# Altium schematic sheet 1_Power_Path.SchDoc
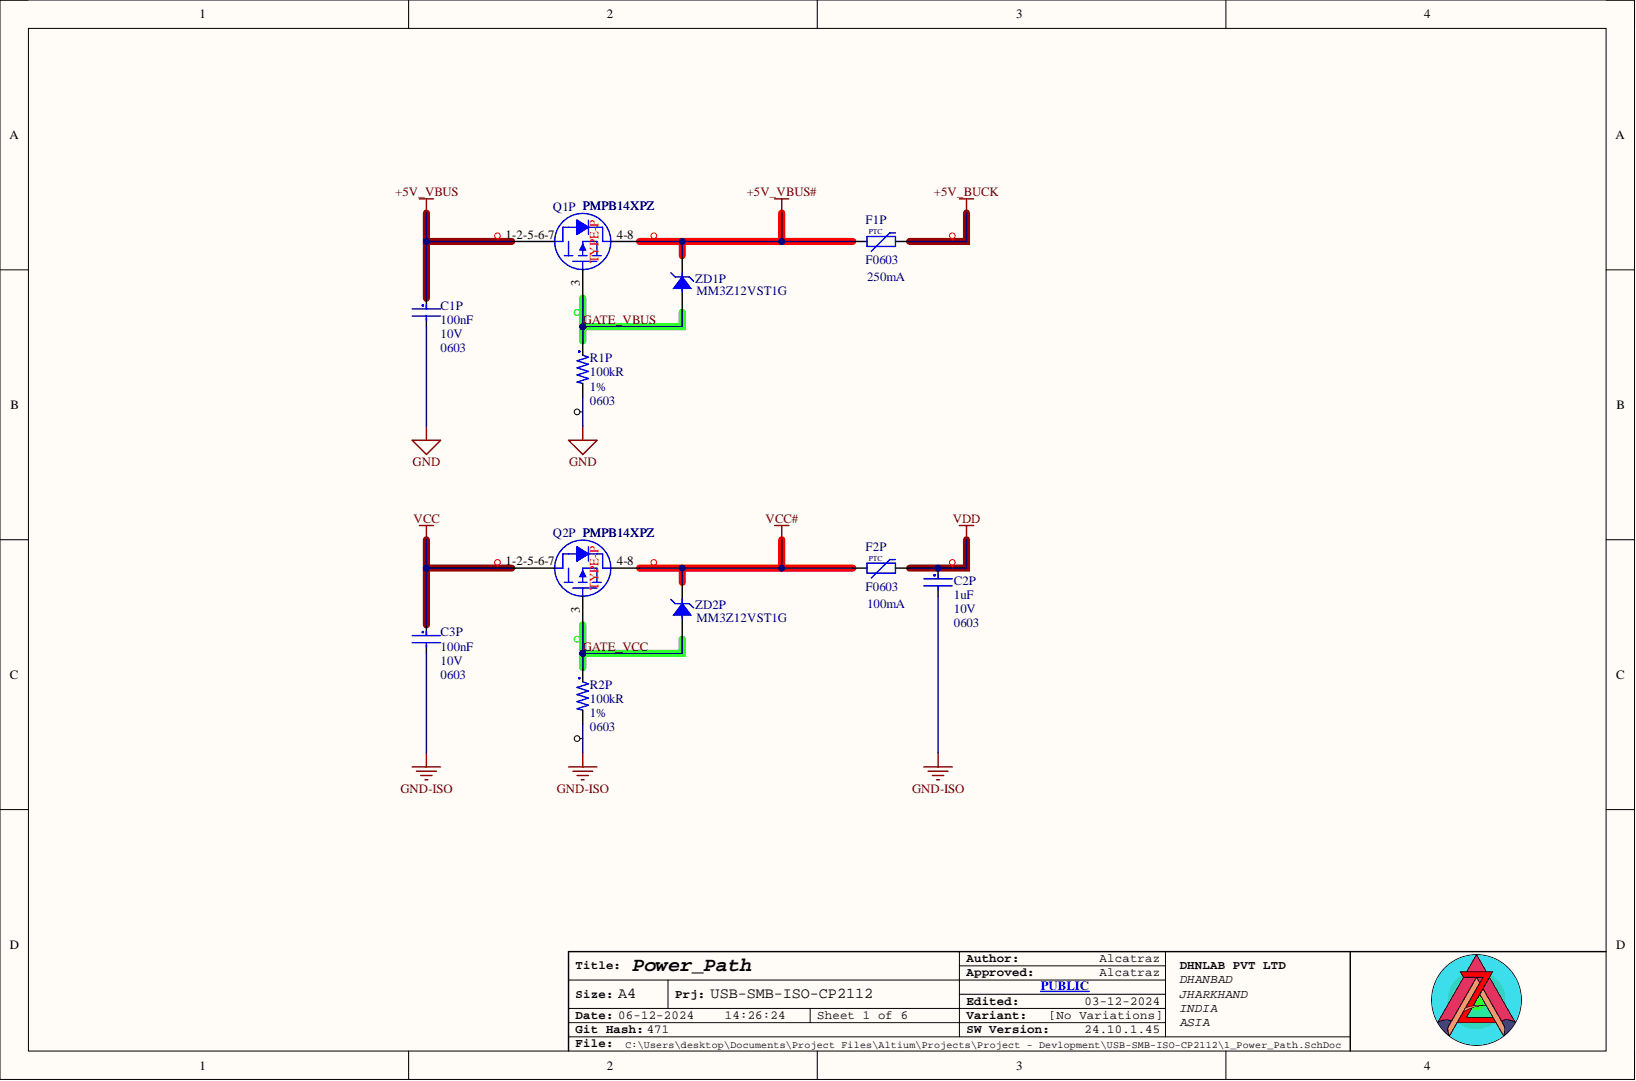
[diagram p0: 1_Power_Path.SchDoc]

## Parts
C1P  value 100nF  Case Code (Imperial): 0603  Voltage: 10V  [C0603-IPC_C_No_Silk]  (hidden comment: C0603C104K8RACTU)
C2P  value 1uF  Case Code (Imperial): 0603  Voltage: 10V  [C0603-IPC_C_No_Silk]  (hidden comment: C0603C105K8PACTU)
C3P  value 100nF  Case Code (Imperial): 0603  Voltage: 10V  [C0603-IPC_C_No_Silk]  (hidden comment: C0603C104K8RACTU)
F1P  F0603  value 250mA  [R0603-MFG]
F2P  F0603  value 100mA  [R0603-MFG]
Q1P  PMPB14XPZ  [DFN2020MD-MFG]
Q2P  PMPB14XPZ  [DFN2020MD-MFG]
R1P  value 100kR  Case Code (Imperial): 0603  Tolerance: 1%  [R0603-MFG]  (hidden comment: AC0603FR-07100KL)
R2P  value 100kR  Case Code (Imperial): 0603  Tolerance: 1%  [R0603-MFG]  (hidden comment: AC0603FR-07100KL)
ZD1P  MM3Z12VST1G  [SOD-323]
ZD2P  MM3Z12VST1G  [SOD-323]

## Nets
+5V_BUCK: F1P.2
+5V_VBUS: C1P.1 Q1P.1-2-5-6-7
+5V_VBUS#: F1P.1 Q1P.4-8 ZD1P.1
GND: C1P.2 R1P.2
GND-ISO: C2P.2 C3P.2 R2P.2
VCC: C3P.1 Q2P.1-2-5-6-7
VCC#: F2P.1 Q2P.4-8 ZD2P.1
VDD: C2P.1 F2P.2
GATE_VBUS: Q1P.3 R1P.1 ZD1P.2
GATE_VCC: Q2P.3 R2P.1 ZD2P.2

## Library data of the parts
C1P C3P = 100nF_10V: Multilayer Ceramic Capacitor, 0.1 uF, 10 V, ± 10%, X7R, 0603 [1608 Metric]; Manufacturer: KEMET; Dissipation Factor: 5%; Material: 4241ConductorMaterial; Temperature Coefficient: 30ppm/°C; Case Code (Metric): 1608; Case/Package: 0603; Category: Ceramic Capacitors; Component Kind: Standard; ComponentLink1Description: https://datasheet.ciiva.com/6093/1776114-6093269.pdf?src-supplier=Farnell; ComponentLink1URL: https://datasheet.ciiva.com/6093/1776114-6093269.pdf?src-supplier=Farnell; ComponentLink2Description: https://datasheet.ciiva.com/7823/kem-c1002-x7r-smd-7823989.pdf?src-supplier=Element14; ComponentLink2URL: https://datasheet.ciiva.com/7823/kem-c1002-x7r-smd-7823989.pdf?src-supplier=Element14; ComponentLink3Description: https://datasheet.ciiva.com/22693/1851736-22693967.pdf?src-supplier=Element14; ComponentLink3URL: https://datasheet.ciiva.com/22693/1851736-22693967.pdf?src-supplier=Element14; ComponentLink4Description: https://datasheet.ciiva.com/14589/70095383-14589402.pdf?src-supplier=Allied+Electronics; ComponentLink4URL: https://datasheet.ciiva.com/14589/70095383-14589402.pdf?src-supplier=Allied+Electronics; ComponentLink5Description: https://datasheet.ciiva.com/14593/70095383-14593978.pdf?src-supplier=Allied+Electronics; ComponentLink5URL: https://datasheet.ciiva.com/14593/70095383-14593978.pdf?src-supplier=Allied+Electronics; ComponentLink6Description: https://datasheet.ciiva.com/5419/kem-c1002-x7r-smd-357932-5419657.pdf?src-supplier=Mouser; ComponentLink6URL: https://datasheet.ciiva.com/5419/kem-c1002-x7r-smd-357932-5419657.pdf?src-supplier=Mouser; ComponentLink7Description: https://datasheet.ciiva.com/18192/getdatasheetpartid-91529-18192711.pdf?src-supplier=Verical; ComponentLink7URL: https://datasheet.ciiva.com/18192/getdatasheetpartid-91529-18192711.pdf?src-supplier=Verical; Construction: Flat; Depth: 0.8mm; Dielectric: X7R; Dielectric Material: Ceramic; Height: 0.8mm; Lead Free: 5697LeadFree; Lead Pitch: 0.7mm; Length: 1.6mm; Library Name: Lib_Capacitor_0603.IntLib; Manufacturer Part Number: C0603C104K8RACTU; Max Operating Temperature: 125°C; Min Operating Temperature: -55°C; Mounting Technology: Surface Mount,PCB; Package Quantity: 1; Packaging: Tape and Reel; Pins: 2; Pricing 1: 1=0.11, 10=0.075, 50=0.075, 100=0.036, 1000=0.021, 10000=0.017 (USD); Radiation Hardening: No; Reach SVHC Compliant: No; RoHS: Yes; RoHS Compliant: Yes; Series: C; Stock 1: 246409; Supplier 1: Mouser; Supplier Part Number 1: 80-C0603C104K8R; Termination: SMD/SMT; Thickness: 0.034inch; Tolerance: 10%; Voltage Rating: 10V; Voltage Rating (DC): 10V; Width: 0.031inch
C2P = 1uF_10V: Ceramic Capacitor, Multilayer, Ceramic, 10V, 10% +Tol, 10% -Tol, X5R, 15% TC, 1uF, 0603; Manufacturer: KEMET; Case Code (Metric): 1608; Case/Package: 0603; Category: Ceramic Capacitors; Component Kind: Standard; Construction: Flat; Depth: 0.8mm; Dielectric: X5R; Dielectric Material: Ceramic; Height: 0.8mm; Lead Free: 5697LeadFree; Length: 1.6mm; Library Name: Lib_Capacitor_0603.IntLib; Manufacturer Part Number: C0603C105K8PACTU; Max Operating Temperature: 85°C; Min Operating Temperature: -55°C; Mounting Technology: Surface Mount; Package Quantity: 1; Packaging: Tape and Reel; Pins: 2; Pricing 1: 1=0.1, 10=0.03, 50=0.03, 100=0.018, 1000=0.014, 10000=0.009 (USD); Radiation Hardening: No; Reach SVHC Compliant: No; RoHS: Yes; RoHS Compliant: Yes; Series: C; Stock 1: 221942; Supplier 1: Mouser; Termination: SMD/SMT; Thickness: 0.035inch; Tolerance: 10%; Voltage Rating: 10V; Voltage Rating (DC): 10V; Weight: 7.1E-05oz; Width: 0.031inch
F1P F2P = FUSE_PPTC_0603: Fuse PPTC SMD 0603; Component Kind: Standard; Library Name: Lib_Fuse.IntLib
Q1P Q2P = PMPB14XPZ: Manufacturer: Nexperia; Component Kind: Standard; Description: PMPB14XPZ; Library Name: Lib_Mosfet_PNP.IntLib; Manufacturer Part Number: PMPB14XPZ; RoHS: No; Stock 1: 3000; Supplier 1: Element14; Supplier Part Number 1: 4177131
R1P R2P = 100K: SMD Chip Resistor, 100 kOhm, ± 1%, 100 mW, 0603 [1608 Metric], Thick Film, General Purpose; Manufacturer: Yageo; Max Power Dissipation: 100mW; Temperature Coefficient: 100ppm/°C; Voltage Rating: 50V; Working Voltage: 75V; Case Code (Metric): 1608; Case/Package: 0603; Category: Chip SMD Resistors; Component Kind: Standard; ComponentLink1Description: https://datasheet.ciiva.com/26862/getdatasheetpartid-715379-26862460.pdf?src-supplier=Verical; ComponentLink1URL: https://datasheet.ciiva.com/26862/getdatasheetpartid-715379-26862460.pdf?src-supplier=Verical; ComponentLink2Description: https://datasheet.ciiva.com/5562/pyu-ac-51-rohs-l-2-5562536.pdf?src-supplier=Mouser; ComponentLink2URL: https://datasheet.ciiva.com/5562/pyu-ac-51-rohs-l-2-5562536.pdf?src-supplier=Mouser; Construction: Rectangular; Depth: 0.8mm; Features: Moisture Resistant,Automotive AEC-Q200; Lead Free: 5697LeadFree; Length: 1.6mm; Library Name: Lib_Register_0603.IntLib; Manufacturer Part Number: AC0603FR-07100KL; Max Operating Temperature: 155°C; Military Standard: Not; Min Operating Temperature: -55°C; Moisture Sensitivity Level: 1; Number of Terminations: 2; Packaging: Tape and Reel; Power Rating: 100mW; Pricing 1: 5000=0.0034, 25000=0.0018, 75000=0.0032, 125000=0.0017, 250000=0.0031, 750000=0.003, 2500000=0.0027 (USD); Ratings: AEC-Q200; RoHS: Yes; RoHS Compliant: Yes; Series: AC; Stock 1: 3790000; Supplier 1: Future Electronics; Supplier Part Number 1: 6029288; Technology: THICK FILM; Termination: SMD/SMT
ZD1P ZD2P = MM3Z12VST1G: MM3Z12VST1G Zener Diode, 12V 2% 200 mW SMT 2-Pin SOD-323 | ON Semiconductor MM3Z12VST1G; Forward Voltage: 900mV; Impedance: 25R; Max Power Dissipation: 300mW; Max Reverse Current: 100nA; Power Dissipation: 200mW; Test Current: 5mA; Zener Current: 10mA; Case/Package: SOD-323-2; Category: Zener Diodes; Component Kind: Standard; ComponentLink1Description: https://datasheet.ciiva.com/30478/0900766b8127c8bd-30478408.pdf?src-supplier=Rs; ComponentLink1URL: https://datasheet.ciiva.com/30478/0900766b8127c8bd-30478408.pdf?src-supplier=Rs; ComponentLink2Description: https://datasheet.ciiva.com/16292/mm3z12vst1g-16292931.pdf?src-supplier=Future+Electronics; ComponentLink2URL: https://datasheet.ciiva.com/16292/mm3z12vst1g-16292931.pdf?src-supplier=Future+Electronics; ComponentLink3Description: https://datasheet.ciiva.com/22626/1878251-22626885.pdf?src-supplier=Element14; ComponentLink3URL: https://datasheet.ciiva.com/22626/1878251-22626885.pdf?src-supplier=Element14; ComponentLink4Description: https://datasheet.ciiva.com/894/mm3z2v4st1-d-894650.pdf?src-supplier=Digikey; ComponentLink4URL: https://datasheet.ciiva.com/894/mm3z2v4st1-d-894650.pdf?src-supplier=Digikey; ComponentLink5Description: https://datasheet.ciiva.com/894/mm3z2v4st1-d-894651.pdf?src-supplier=Digikey; ComponentLink5URL: https://datasheet.ciiva.com/894/mm3z2v4st1-d-894651.pdf?src-supplier=Digikey; ComponentLink6Description: https://datasheet.ciiva.com/894/mm3z2v4st1-d-894649.pdf?src-supplier=Digikey; ComponentLink6URL: https://datasheet.ciiva.com/894/mm3z2v4st1-d-894649.pdf?src-supplier=Digikey; Height: 1mm; Lead Free: Lead Free; Length: 1.8mm; Library Name: Lib_Diodes.IntLib; Manufacturer: ON Semiconductor; Manufacturer Part Number: MM3Z12VST1G; Material: Plastic; Max Operating Temperature: 150°C; Max Reverse Leakage Current: 100nA; Min Operating Temperature: -65°C; Package Quantity: 3000; Packaging: Tape and Reel; Pins: 2; Power Rating: 200mW; Pricing 1: 3000=0.0152, 6000=0.0145, 9000=0.0143, 27000=0.0137, 51000=0.0133, 102000=0.0128, 252000=0.0125, 501000=0.0123 (USD); Radiation Hardening: No; Reach SVHC Compliant: No; RoHS: Yes; RoHS Compliant: Yes; Series: -; Stock 1: 60000; Supplier 1: Onlinecomponents.com; Supplier Part Number 1: MM3Z12VST1G; Tolerance: 2%; Voltage Rating (DC): 12V; Voltage Tolerance: 2%; Width: 1.35mm; Working Voltage: 12V; Zener Voltage: 12V
